# Altium schematic sheet 4_SD_Card_NFC.SchDoc
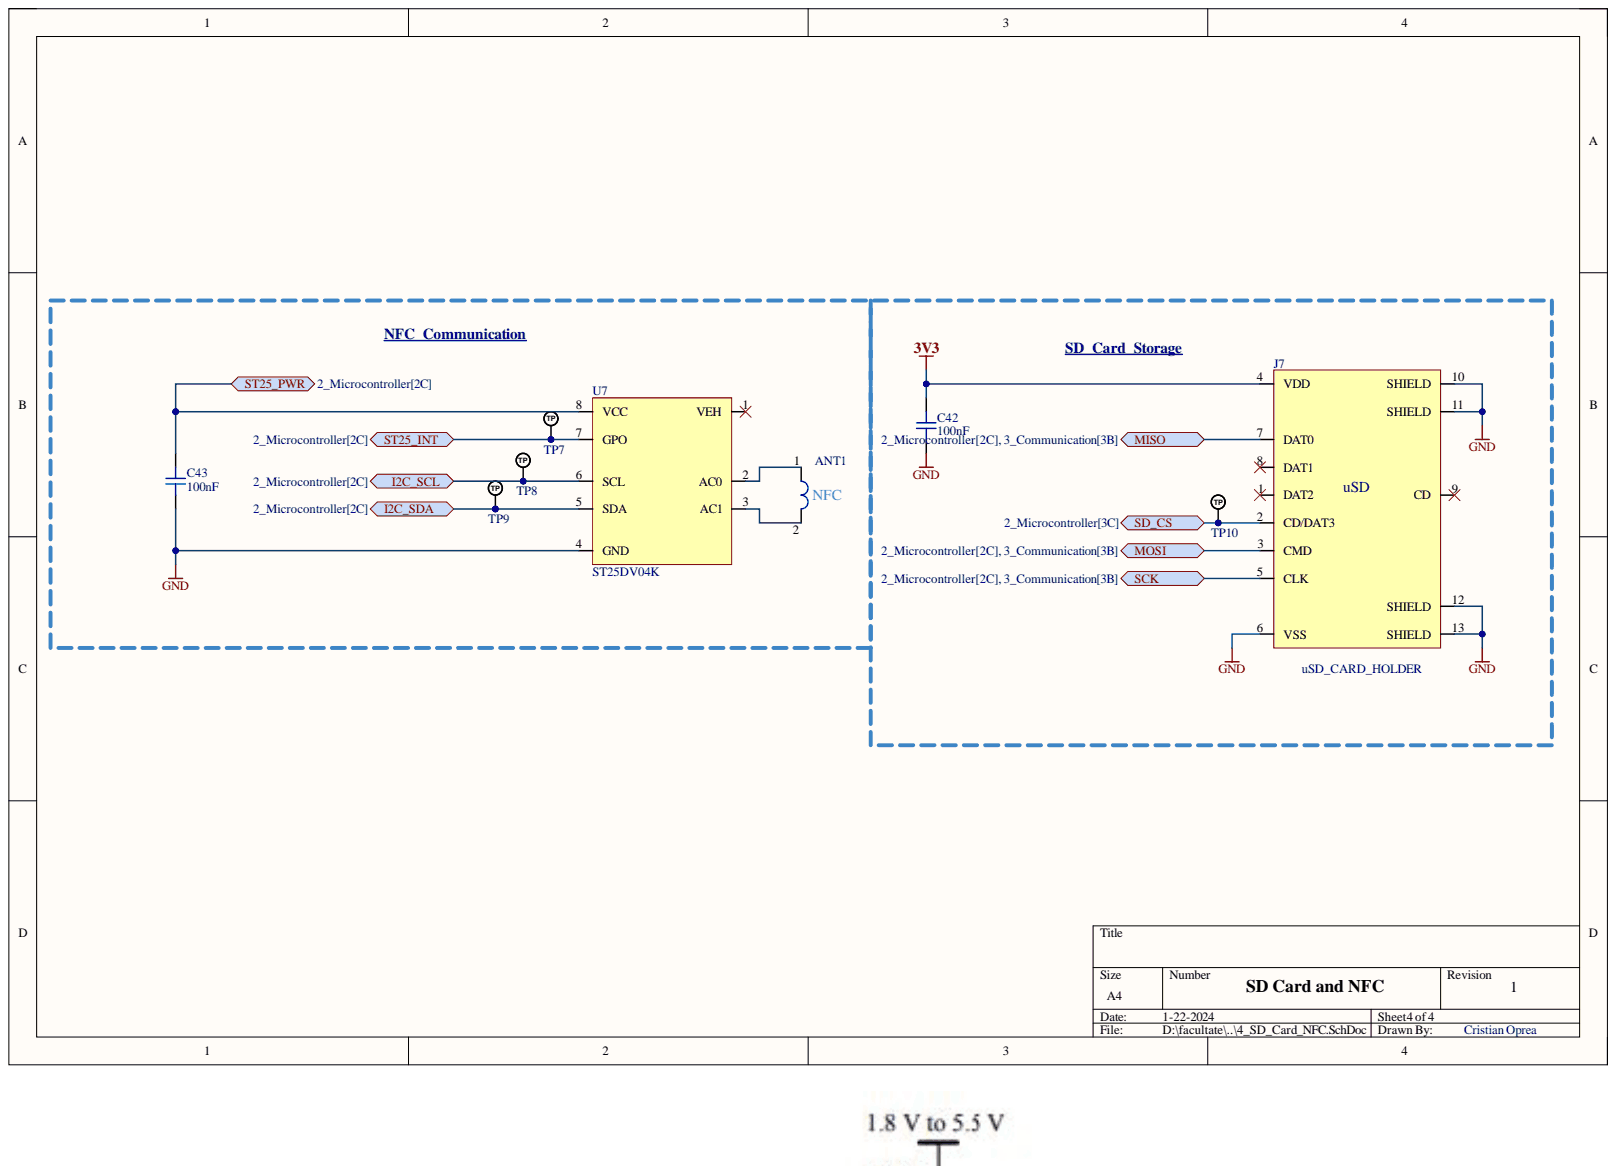
[diagram p0: 4_SD_Card_NFC.SchDoc]

## Parts
ANT1  NFC_ANTENNA  [ANT16]  (value not drawn)
C42  100nF  [C0603]
C43  100nF  [C0603]
J7  uSD_CARD_HOLDER  [TF115BCP9]
TP7  TP_NOBOM  [TEST_PAD_THD]  (value not drawn)  (no BOM)
TP8  TP_NOBOM  [TEST_PAD_THD]  (value not drawn)  (no BOM)
TP9  TP_NOBOM  [TEST_PAD_THD]  (value not drawn)  (no BOM)
TP10  TP_NOBOM  [TEST_PAD_THD]  (value not drawn)  (no BOM)
U7  ST25DV04K  [SOIC-SN8_N]

## Ports
I2C_SCL, I2C_SDA, MISO, MOSI, SCK, SD_CS, ST25_INT, ST25_PWR

## Nets
3V3: C42.2 J7.4(VDD)
GND: C42.1 C43.1 J7.6(VSS) J7.10(SHIELD) J7.11(SHIELD) J7.12(SHIELD) J7.13(SHIELD) U7.4(GND)
I2C_SCL: TP8.1 U7.6(SCL) ; port I2C_SCL
I2C_SDA: TP9.1 U7.5(SDA) ; port I2C_SDA
MISO: J7.7(DAT0) ; port MISO
MOSI: J7.3(CMD) ; port MOSI
SCK: J7.5(CLK) ; port SCK
SD_CS: J7.2(CD/DAT3) TP10.1 ; port SD_CS
ST25_INT: TP7.1 U7.7(GPO) ; port ST25_INT
ST25_PWR: C43.2 U7.8(VCC) ; port ST25_PWR
NetANT1_1: ANT1.1 U7.2(AC0)
NetANT1_2: ANT1.2 U7.3(AC1)

Not connected: J7 1(DAT2) 8(DAT1) 9(CD); U7 1(VEH)

## Library data of the parts
C42 C43 = CAP_0603: Capacitor SMD 0603; Component Kind: Standard
  C42: Library Name: Schlib_H2.SchLib
  C43: Library Name: Schlib1.SchLib
J7 = uSD_CARD: Component Kind: Standard; Library Name: Schlib_H2.SchLib
TP7-TP10 = TEST_POINT_RND_1MM: Test Point Round 1mm (NO BOM); Library Name: Schlib_H2.SchLib
U7 = ST25DV04K: ST25DO4K NFC module with I2C; Component Kind: Standard; Library Name: Schlib1.SchLib
ANT1 = NFC_ANTENNA: Component Kind: Standard; Library Name: Schlib1.SchLib
